# Altium schematic sheet Power.SchDoc(Power)
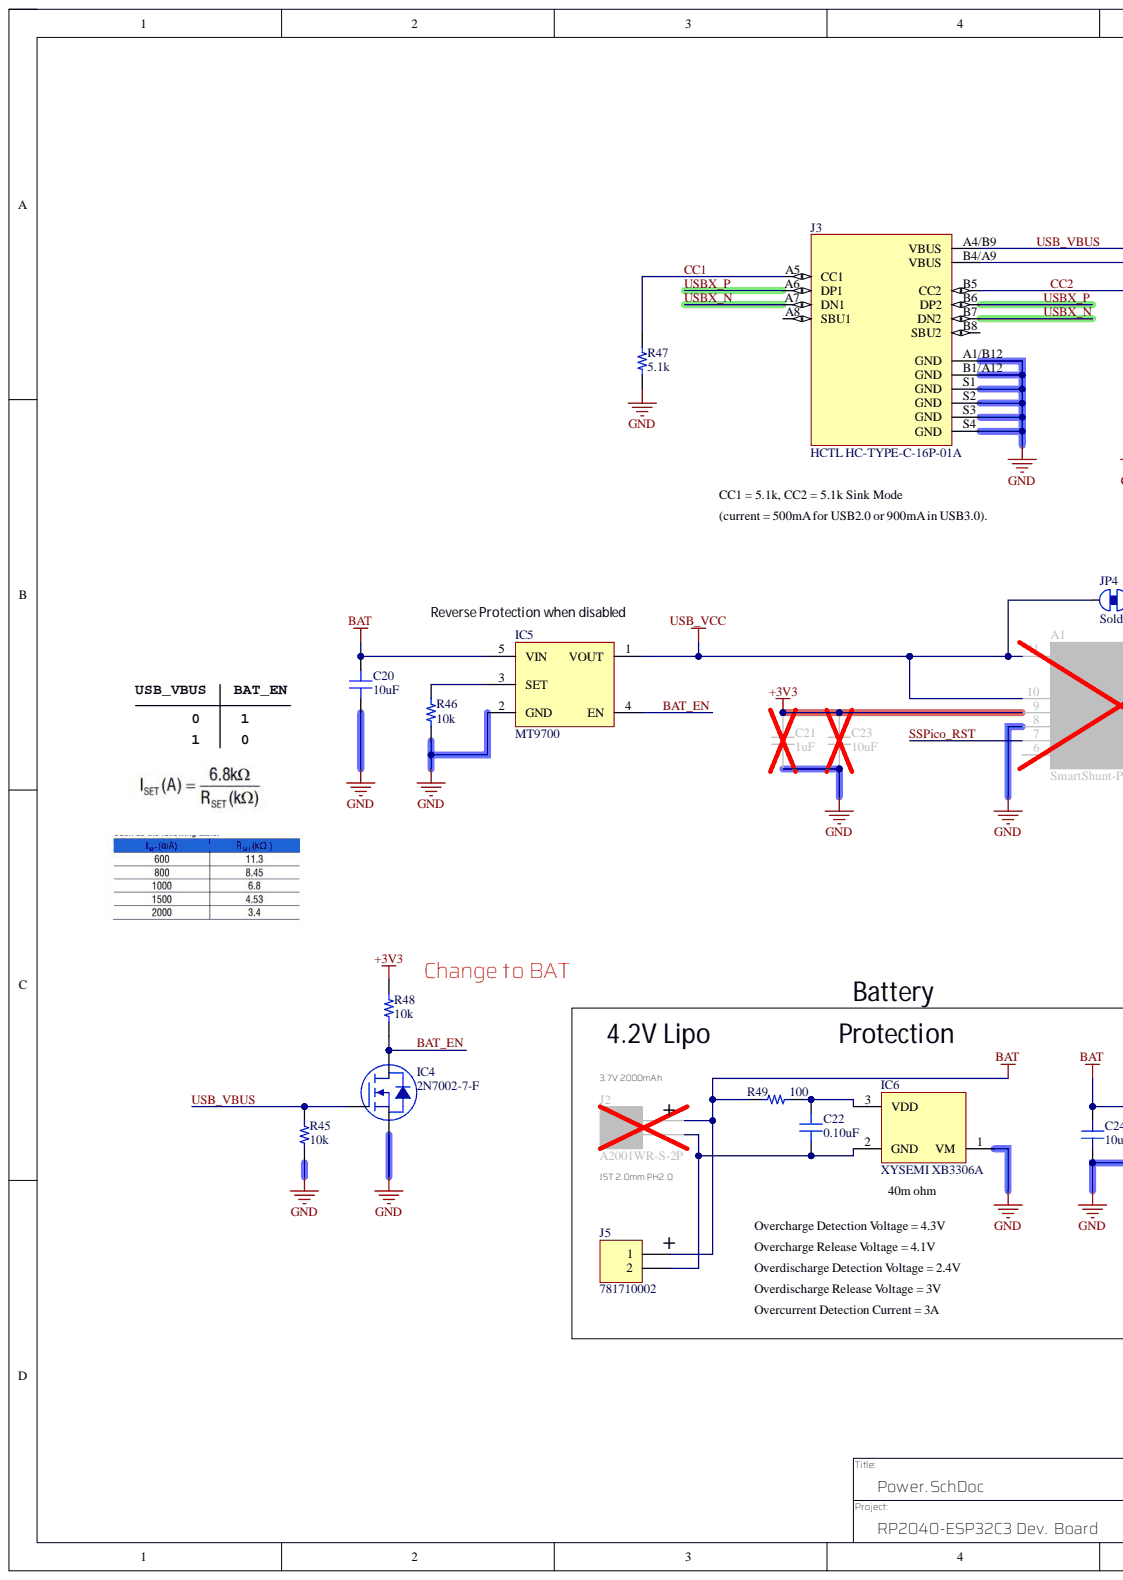
[diagram p0: Power.SchDoc, left]
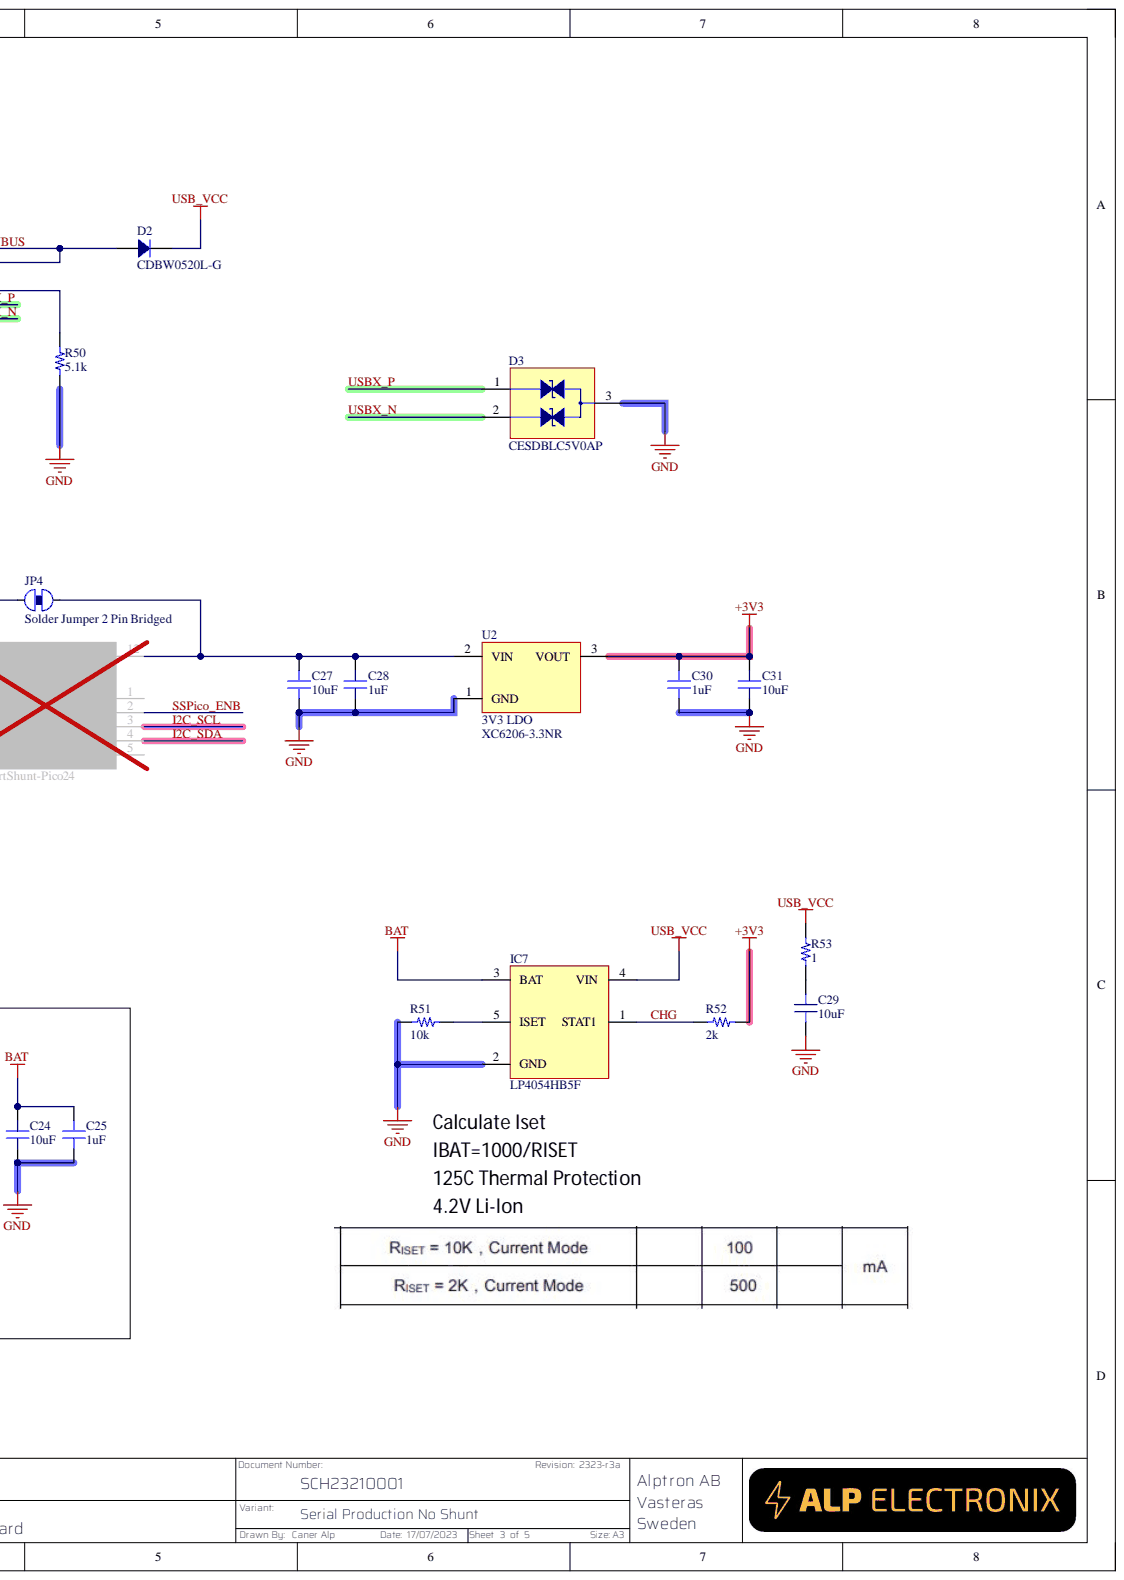
[diagram p1: Power.SchDoc, right]

## Parts
A1  SmartShunt-Pico24  [SmartShunt-Pico24]
C20  10uF  [CAP 0603/1608]
C21  1uF  [CAP 0402/1005]
C22  0.10uF  [CAP 0402/1005]
C23  10uF  [CAP 0603/1608]
C24  10uF  [CAP 0603/1608]
C25  1uF  [CAP 0402/1005]
C27  10uF  [CAP 0603/1608]
C28  1uF  [CAP 0402/1005]
C29  10uF  [CAP 0603/1608]
C30  1uF  [CAP 0402/1005]
C31  10uF  [CAP 0603/1608]
D2  CDBW0520L-G  [SOD-123]
D3  CESDBLC5V0AP  [ON SEMI SOT-23-3]
IC4  2N7002-7-F  [DIODES SOT-23-3]
IC5  MT9700  [DIODES SOT-23-5 W5]
IC6  XYSEMI XB3306A  Manufacturer: XYSEMI  [DIODES SOT-23-3]
IC7  LP4054HB5F  [DIODES SOT-23-5 W5]
J2  A2001WR-S-2P  [JST S2B-PH-SM4-TB]
J3  HCTL HC-TYPE-C-16P-01A  [HCTL HC-TYPE-C-16P-01A]
J5  781710002  [Molex 781710002]
JP4  Solder Jumper 2 Pin Bridged
R45  10k  [RES 0402/1005]
R46  10k  [RES 0603/1608]
R47  5.1k  [RES 0402/1005]
R48  10k  [RES 0402/1005]
R49  100  [RES 0402/1005]
R50  5.1k  [RES 0402/1005]
R51  10k  [RES 0603/1608]
R52  2k  [RES 0402/1005]
R53  1  [RES 0402/1005]
U2  3V3 LDO  [DIODES SOT-23-3]

## Nets
+3V3: A1.9(VDD) C21.2 C23.2 C30.2 C31.2 R48.2 R52.2 U2.3
BAT: C20.2 C24.2 C25.2 IC5.5(VIN) IC7.3(BAT) J2.2 J5.1 R49.1
GND: A1.8(GND) C20.1 C21.1 C23.1 C24.1 C25.1 C27.1 C28.1 C29.1 C30.1 C31.1 D3.3 IC4.3 IC5.2(GND) IC6.1 IC7.2(GND) J3.A1/B12(GND) J3.B1/A12(GND) J3.S1(GND) J3.S2(GND) J3.S3(GND) J3.S4(GND) R45.1 R46.1 R47.1 R50.1 R51.1 U2.1
USB_VCC: A1.10(AVM) A1.11(CURR+) D2.2 IC5.1(VOUT) IC7.4(VIN) JP4.1 R53.2
BAT_EN: IC4.2 IC5.4(EN) R48.1
CC1: J3.A5(CC1) R47.2
CC2: J3.B5(CC2) R50.2
CHG: IC7.1(STAT1) R52.1
I2C_SCL: A1.3(I2C_SCL)
I2C_SDA: A1.4(I2C_SDA)
SSPico_ENB: A1.2(PA3)
SSPico_RST: A1.7(RST)
USB_VBUS: D2.1 IC4.1 J3.A4/B9(VBUS) J3.B4/A9(VBUS) R45.2
USBX_N: D3.2 J3.A7(DN1) J3.B7(DN2)
USBX_P: D3.1 J3.A6(DP1) J3.B6(DP2)
NetA1_12: A1.12(CURR-) C27.2 C28.2 JP4.2 U2.2
NetC22_1: C22.1 IC6.2 J2.1 J5.2
NetC22_2: C22.2 IC6.3 R49.2
NetC29_2: C29.2 R53.1
NetIC5_3: IC5.3(SET) R46.2
NetIC7_5: IC7.5(ISET) R51.2

Not connected: A1 1(PA2) 5(PB1) 6(PA10); J3 A8(SBU1) B8(SBU2)

## Library data of the parts
A1 = SmartShunt-Pico24: Current Measurement Module; Component Kind: Standard; Library Name: RP2040_ESP32-C3 Dev Board SCH Lib.SchLib
C20 C23 C24 C27 C29 C31 = GRM188R60J106ME47D: CAP CER 10UF 6.3V X5R 0603; Manufacturer: Murata Electronics North America; Database Table Name: Capacitor - Ceramic; TemperatureRange: -55C ~ 85C; Tolerance: 20%; Component Kind: Standard; ComponentLink1Description: Datasheet; ComponentLink1URL: http://www.murata.com/~/media/webrenewal/support/library/catalog/products/capacitor/mlcc/c02e.pdf; ComponentLink2Description: Digi-Key Listing; ComponentLink2URL: https://www.digikey.com/product-detail/en/murata-electronics-north-america/GRM188R60J106ME47D/490-3896-2-ND/965910; Device Package: 0603 (1608 Metric); Library Name: Celestial Library Database.DbLib; Part Number: GRM188R60J106ME47D; PartId: 12950; Price: 0,031; Supplier 1: Digi-Key; Supplier Part Number 1: 490-3896-2-ND; TemperatureCoefficient: X5R; Value: 10uF; Voltage: 6.3V
C21 C25 C28 C30 = GRM155R70J105KA12D: CAP CER 1UF 6.3V X7R 0402; Manufacturer: Murata Electronics North America; Database Table Name: Capacitor - Ceramic; TemperatureRange: -55C ~ 125C; Tolerance: 10%; Type: Derating Recommended; Component Kind: Standard; ComponentLink1Description: Datasheet; ComponentLink1URL: http://www.murata.com/~/media/webrenewal/support/library/catalog/products/capacitor/mlcc/c02e.ashx; ComponentLink2Description: Digi-Key listing; ComponentLink2URL: http://digikey.com/product-detail/en/GRM155R70J105KA12D/490-10024-2-ND/5027109; Device Package: 0402 (1005 Metric); Library Name: Celestial Library Database.DbLib; Part Number: GRM155R70J105KA12D; PartId: 5157; Price: 0,019; Supplier 1: Digi-Key; Supplier Part Number 1: 490-10024-2-ND; TemperatureCoefficient: X7R; Value: 1uF; Voltage: 6.3V
C22 = LMK105BJ104KV-F: CAP CER 0.1UF 10V X5R 0402; Manufacturer: Taiyo Yuden; Database Table Name: Capacitor - Ceramic; TemperatureRange: -55C ~ 85C; Tolerance: 10%; Component Kind: Standard; ComponentLink1Description: Datasheet; ComponentLink1URL: http://ds.yuden.co.jp/TYCOMPAS/ut/detail.do?productNo=LMK105BJ104KV-F&fileName=LMK105BJ104KV-F_SS&mode=specSheetDownload; ComponentLink2Description: Digi-Key Listing; ComponentLink2URL: https://www.digikey.com/product-detail/en/taiyo-yuden/LMK105BJ104KV-F/587-1227-2-ND/930579; Device Package: 0402 (1005 Metric); Library Name: Celestial Library Database.DbLib; Part Number: LMK105BJ104KV-F; PartId: 12322; Price: 0,0021; Supplier 1: Digi-Key; Supplier Part Number 1: 587-1227-2-ND; TemperatureCoefficient: X5R; Value: 0.10uF; Voltage: 10V
D2 = CDBW0520L-G: DIODE SCHOTTKY 20V 500MA SOD123; Manufacturer: Comchip Technology; Current - Reverse Leakage @ Vr: 250 µA @ 20 V; Database Table Name: Diodes - Rectifiers - Single; Speed: Fast Recovery =< 500ns, > 200mA (Io); Voltage - Forward (Vf) (Max) @ If: 385 mV @ 500 mA; Component Kind: Standard; ComponentLink1Description: Datasheet; ComponentLink1URL: http://www.comchiptech.com/admin/files/product/CDBW0520L-HF Thru824572. CDBW0540-HF RevA.pdf; ComponentLink2Description: DigiKey Link; ComponentLink2URL: https://www.digikey.com/en/products/detail/comchip-technology/CDBW0520L-G/1979670; Current - Average Rectified (Io): 500mA; Diode Type: Schottky; LastUpdated: 2022-06-30 22:48:20; Library Name: Celestial Altium Library - altium_library.DbLib; Lifecycle Status: Active; Minimum Order: 1; Mounting Type: Surface Mount; Operating Temperature - Junction: 125°C (Max); Package / Case: SOD-123; Packaging: TapeAndReel; Part Number: CDBW0520L-G; Part Status: Active; PartId: 2251; Price: 0.07; Series: -; Supplier 1: DigiKey; Supplier Device Package: SOD-123; Supplier Part Number 1: 641-1326-1-ND; Voltage - DC Reverse (Vr) (Max): 20 V
D3 = NUP2105LT1G: TVS DIODE 24V 44V SOT23-3; Manufacturer: ON Semiconductor; Bidirectional Channels: 2; Capacitance @ Frequency: 30pF @ 1MHz; Current - Peak Pulse (10/1000µs): 8A (8/20µs); Database Table Name: Diodes - TVS; Power - Peak Pulse: 350W; Power Line Protection: No; Type: Zener; Voltage - Breakdown (Min): 26.2V; Voltage - Reverse Standoff (Typ): 24V (Min); Applications: General Purpose; Component Kind: Standard; ComponentLink1Description: Datasheet; ComponentLink1URL: http://www.onsemi.com/pub/Collateral/NUP2105L-D.PDF; ComponentLink2Description: DigiKey Link; ComponentLink2URL: http://digikey.com/product-detail/en/on-semiconductor/NUP2105LT1G/NUP2105LT1GOSCT-ND/1485001; Device Package: TO-236-3, SC-59, SOT-23-3; LastUpdated: 2020-03-30 16:33:57; Library Name: Celestial Altium Library - altium_library.DbLib; Lifecycle Status: Active; Minimum Order: 1; Mounting Type: Surface Mount; Operating Temperature: -55°C ~ 150°C (TJ); Package / Case: TO-236-3, SC-59, SOT-23-3; Packaging: TapeAndReel; Part Number: CESDBLC5V0AP; Part Status: Active; PartId: 10506; Price: 0.09; Series: -; Supplier 1: DigiKey; Supplier Device Package: SOT-23-3 (TO-236); Supplier Part Number 1: NUP2105LT1GOSCT-ND; Voltage - Clamping (Max) @ Ipp: 44V
IC4 = 2N7002-7-F: MOSFET N-CH 60V 115MA SOT23-3; Manufacturer: Diodes Incorporated; Current Continuous Drain: 115mA (Ta); Database Table Name: N-Channel FET; Input Capacitance (Ciss) at Vds: 50pF @ 25V; Power - Max: 370mW; Rds On (Max) at Id, Vgs: 7.5 Ohm @ 50mA, 5V; Vgs(th) (Max) at Id: 2.5V @ 250uA; Component Kind: Standard; ComponentLink1Description: Datasheet; ComponentLink1URL: http://www.diodes.com/_files/datasheets/ds11303.pdf; ComponentLink2Description: Digi-Key Listing; ComponentLink2URL: http://www.digikey.com/product-detail/en/2N7002-7-F/2N7002-FDITR-ND/717681; Device Package: TO-236-3, SC-59, SOT-23-3; Drain to Source Voltage (Vdss): 60V; Features: Standard; Gate Charge (Qg): -; Library Name: Celestial Library Database.DbLib; Part Number: 2N7002-7-F; PartId: 7967; Price: 0,0255; Supplier 1: Digi-Key; Supplier Part Number 1: 2N7002-FDITR-ND; Type: MOSFET N-Channel, Metal Oxide
IC5 = MT9700: SOT-23-5 Power Distribution Switches ROHS; Component Kind: Standard; Library Name: RP2040_ESP32-C3 Dev Board SCH Lib.SchLib
IC6 = XYSEMI XB3306A: IC LITHIUM BATT PROTECT SOT23-3; Battery Chemistry: Li-Ion, Li-Pol; Database Table Name: Charger; Function: Protection; Component Kind: Standard; ComponentLink1Description: Datasheet; ComponentLink1URL: http://www.baitaishengshi.com/uploadfile/2017/1213/20171213368970.pdf; ComponentLink2Description: LCSC Listing; ComponentLink2URL: https://lcsc.com/product-detail/Battery-Protection-ICs_XB3306A_C154939.html; Device Package: SOT-23-3; Library Name: Celestial Library Database.DbLib; Part Number: XYSEMI XB3306A; PartId: 16446; Supplier 1: LCSC; Supplier Part Number 1: C154939
IC7 = LP4054HB5F: 600mA Standalone Linear Li-Ion Battery Charger; Component Kind: Standard; Library Name: RP2040_ESP32-C3 Dev Board SCH Lib.SchLib
J2 = S2B-PH-SM4-TB(LF)(SN): CONN HEADER SMD R/A 2POS 2MM; Manufacturer: JST Sales America Inc.; Contact Length - Mating: 0.130" (3.30mm); Current Rating (Amps): 2A; Database Table Name: Connectors - Rectangular Headers Male Pins; Material Flammability Rating: UL94 V-0; Number of Positions: 2; Number of Positions Loaded: All; Pitch - Mating: 0.079" (2.00mm); Shrouding: Shrouded - 4 Wall; Style: Board to Cable/Wire; Voltage Rating: 100V; Component Kind: Standard; ComponentLink1Description: Datasheet; ComponentLink1URL: http://www.jst-mfg.com/product/pdf/eng/ePH.pdf; ComponentLink2Description: DigiKey Link; ComponentLink2URL: http://digikey.com/product-detail/en/jst-sales-america-inc/S2B-PH-SM4-TB-LF-SN/455-1749-1-ND/926846; Connector Type: Header; Contact Finish - Mating: Tin; Contact Finish - Post: Tin; Contact Material: Copper Alloy; Contact Shape: Square; Contact Type: Male Pin; Fastening Type: Detent Lock; Features: Solder Retention; Insulation Color: Natural; Insulation Height: 0.217" (5.51mm); Insulation Material: Polyamide (PA6T), Nylon 6T; LastUpdated: 2020-01-15 03:45:11; Library Name: Celestial Altium Library - altium_library.DbLib; Lifecycle Status: Active; Minimum Order: 1; Mounting Type: Surface Mount, Right Angle; Number of Rows: 1; Operating Temperature: -25°C ~ 85°C; Packaging: TapeAndReel; Part Number: S2B-PH-SM4-TB(LF)(SN); Part Status: Active; PartId: 115; Price: 0.27; Series: PH; Supplier 1: DigiKey; Supplier Part Number 1: 455-1749-1-ND; Termination: Solder
J3 = HIRO TYPE-C-31-M-12: CONN USB 2.0 TYPE-C R/A SMT; Manufacturer: Hiro; Database Table Name: Connector - USB; Number Of Positions: 12; Component Kind: Standard; ComponentLink1Description: Datasheet; ComponentLink1URL: https://datasheet.lcsc.com/szlcsc/1811131825_Korean-Hroparts-Elec-TYPE-C-31-M-12_C165948.pdf; ComponentLink2Description: LCSC Listing; ComponentLink2URL: https://lcsc.com/product-detail/USB-Type-C_Korean-Hroparts-Elec-TYPE-C-31-M-12_C165948.html; Library Name: Celestial Library Database.DbLib; Part Number: HCTL HC-TYPE-C-16P-01A; PartId: 9703; Series: USB 3.0; Supplier 1: LCSC; Supplier Part Number 1: C165948; Type: USB - C
J5 = Header 2: Pico-EZmate Wire-to-Board Header Vertical Housing Height 1.55mm with Side Friction Locks 2 Circuits; Manufacturer: Molex; Manufacturer Part Number: 78171-0002; Contact Resistance: 20mR; Current Rating: 1.5A; Gender: Male; Glow Wire Compliant: Non-compliant; Max Voltage Rating (DC): 5.05kV; Number of Circuits: 2; Pitch: 1.2mm; Voltage Rating: 50V; Category: Headers and Wire Housings; Component Kind: Standard; Contact Gender: Female; Contact Material: Copper Alloy,Copper,Gold; Contact Plating: Gold; Halogen Free: Low Halogen; Housing Material: Liquid Crystal Polymer; Library Name: Miscellaneous Connectors.IntLib; Max Operating Temperature: 85°C; Min Operating Temperature: -25°C; Mounting Technology: Surface Mount; Number of Contacts: 2; Number of Positions: 2; Number of Rows: 1; Orientation: Vertical; Package Quantity: 36000; Packaging: Tape and Reel; Pricing 1: 1=0.39, 10=0.302, 50=0.302, 100=0.225, 1000=0.179, 10000=0.139 (USD); Reach SVHC Compliant: Unknown; RoHS: Yes; RoHS Compliant: Yes; Series: Pico-Ezmate; Stock 1: 144410; Supplier 1: Mouser; Supplier Part Number 1: 538-78171-0002; Termination: Solder; Voltage Rating (AC): 50V
JP4 = SolderJumper_2_Bridged: ki_description: Solder Jumper, 2-pole, closed/bridged; ki_fp_filters: SolderJumper*Bridged*; ki_keywords: solder jumper SPST; Component Kind: Net Tie; Library Name: Jumper.SchLib; Supplier: ~
R45 R48 = RC0402JR-0710KL: RES SMD 10K OHM 5% 1/16W 0402; Manufacturer: Yageo; Database Table Name: Resistor - Chip; PowerRating: 0.063W, 1/16W; TemperatureCoefficient: 100ppm/C; TemperatureRange: -55C ~ 155C; Component Kind: Standard; ComponentLink1Description: Datasheet; ComponentLink1URL: http://www.yageo.com/documents/recent/PYu-RC_Group_51_RoHS_L_04.pdf; ComponentLink2Description: Digi-Key Listing; ComponentLink2URL: https://www.digikey.com/product-detail/en/yageo/RC0402JR-0710KL/311-10KJRTR-ND/726418; Device Package: 0402 (1005 Metric); Library Name: Celestial Library Database.DbLib; Part Number: RC0402JR-0710KL; PartId: 14464; Price: 0,0017; Supplier 1: Digi-Key; Supplier Part Number 1: 311-10KJRTR-ND; Tolerance: 5%; Value: 10k
R46 R51 = ERJ-3GEYJ103V: RES SMD 10K OHM 5% 1/10W 0603; Manufacturer: Panasonic Electronic Components; Database Table Name: Resistor - Chip; PowerRating: 0.1W, 1/10W; TemperatureCoefficient: 200ppm/C; TemperatureRange: -55C ~ 155C; Component Kind: Standard; ComponentLink1Description: Datasheet; ComponentLink1URL: http://industrial.panasonic.com/www-cgi/jvcr13pz.cgi?E+PZ+3+AOA0001+ERJ3GEYJ103V+7+WW; ComponentLink2Description: Digi-Key Listing; ComponentLink2URL: https://www.digikey.com/product-detail/en/panasonic-electronic-components/ERJ-3GEYJ103V/P10KGTR-ND/135662; Device Package: 0603 (1608 Metric); Library Name: Celestial Library Database.DbLib; Part Number: ERJ-3GEYJ103V; PartId: 11175; Price: 0,002; Supplier 1: Digi-Key; Supplier Part Number 1: P10KGTR-ND; Tolerance: 5%; Value: 10k
R47 R50 = RC0402JR-075K1L: RES SMD 5.1K OHM 5% 1/16W 0402; Manufacturer: Yageo; Database Table Name: Resistor - Chip; PowerRating: 0.063W, 1/16W; TemperatureCoefficient: 100ppm/C; TemperatureRange: -55C ~ 155C; Component Kind: Standard; ComponentLink1Description: Datasheet; ComponentLink1URL: http://www.yageo.com/documents/recent/PYu-RC_Group_51_RoHS_L_04.pdf; ComponentLink2Description: Digi-Key Listing; ComponentLink2URL: https://www.digikey.com/product-detail/en/yageo/RC0402JR-075K1L/311-5.1KJRTR-ND/726487; Device Package: 0402 (1005 Metric); Library Name: Celestial Library Database.DbLib; Part Number: RC0402JR-075K1L; PartId: 14528; Price: 0,0017; Supplier 1: Digi-Key; Supplier Part Number 1: 311-5.1KJRTR-ND; Tolerance: 5%; Value: 5.1k
R49 = RC0402JR-07100RL: RES SMD 100 OHM 5% 1/16W 0402; Manufacturer: Yageo; Database Table Name: Resistor - Chip; PowerRating: 0.063W, 1/16W; TemperatureCoefficient: 100ppm/C; TemperatureRange: -55C ~ 155C; Component Kind: Standard; ComponentLink1Description: Datasheet; ComponentLink1URL: http://www.yageo.com/documents/recent/PYu-RC_Group_51_RoHS_L_04.pdf; ComponentLink2Description: Digi-Key Listing; ComponentLink2URL: https://www.digikey.com/product-detail/en/yageo/RC0402JR-07100RL/311-100JRTR-ND/726415; Device Package: 0402 (1005 Metric); Library Name: Celestial Library Database.DbLib; Part Number: RC0402JR-07100RL; PartId: 14478; Price: 0,0017; Supplier 1: Digi-Key; Supplier Part Number 1: 311-100JRTR-ND; Tolerance: 5%; Value: 100
R52 = RC0402JR-072KL: RES SMD 2K OHM 5% 1/16W 0402; Manufacturer: Yageo; Database Table Name: Resistor - Chip; PowerRating: 0.063W, 1/16W; TemperatureCoefficient: 100ppm/C; TemperatureRange: -55C ~ 155C; Component Kind: Standard; ComponentLink1Description: Datasheet; ComponentLink1URL: http://www.yageo.com/documents/recent/PYu-RC_Group_51_RoHS_L_04.pdf; ComponentLink2Description: Digi-Key Listing; ComponentLink2URL: https://www.digikey.com/product-detail/en/yageo/RC0402JR-072KL/311-2.0KJRTR-ND/726434; Device Package: 0402 (1005 Metric); Library Name: Celestial Library Database.DbLib; Part Number: RC0402JR-072KL; PartId: 14511; Price: 0,0017; Supplier 1: Digi-Key; Supplier Part Number 1: 311-2.0KJRTR-ND; Tolerance: 5%; Value: 2k
R53 = RC0402JR-071RL: RES SMD 1 OHM 5% 1/16W 0402; Manufacturer: Yageo; Database Table Name: Resistor - Chip; PowerRating: 0.063W, 1/16W; TemperatureCoefficient: 200ppm/C; TemperatureRange: -55C ~ 155C; Component Kind: Standard; ComponentLink1Description: Datasheet; ComponentLink1URL: http://www.yageo.com/documents/recent/PYu-RC_Group_51_RoHS_L_04.pdf; ComponentLink2Description: Digi-Key Listing; ComponentLink2URL: https://www.digikey.com/product-detail/en/yageo/RC0402JR-071RL/311-1.0JRTR-ND/726407; Device Package: 0402 (1005 Metric); Library Name: Celestial Library Database.DbLib; Part Number: RC0402JR-071RL; PartId: 14504; Price: 0,0017; Supplier 1: Digi-Key; Supplier Part Number 1: 311-1.0JRTR-ND; Tolerance: 5%; Value: 1
U2 = XC6206-3.3NR: Component Kind: Standard; Library Name: RP2040_ESP32-C3 Dev Board SCH Lib.SchLib; MPN: XC6206-3.3NR
